# Altium schematic sheet Sheet3.SchDoc
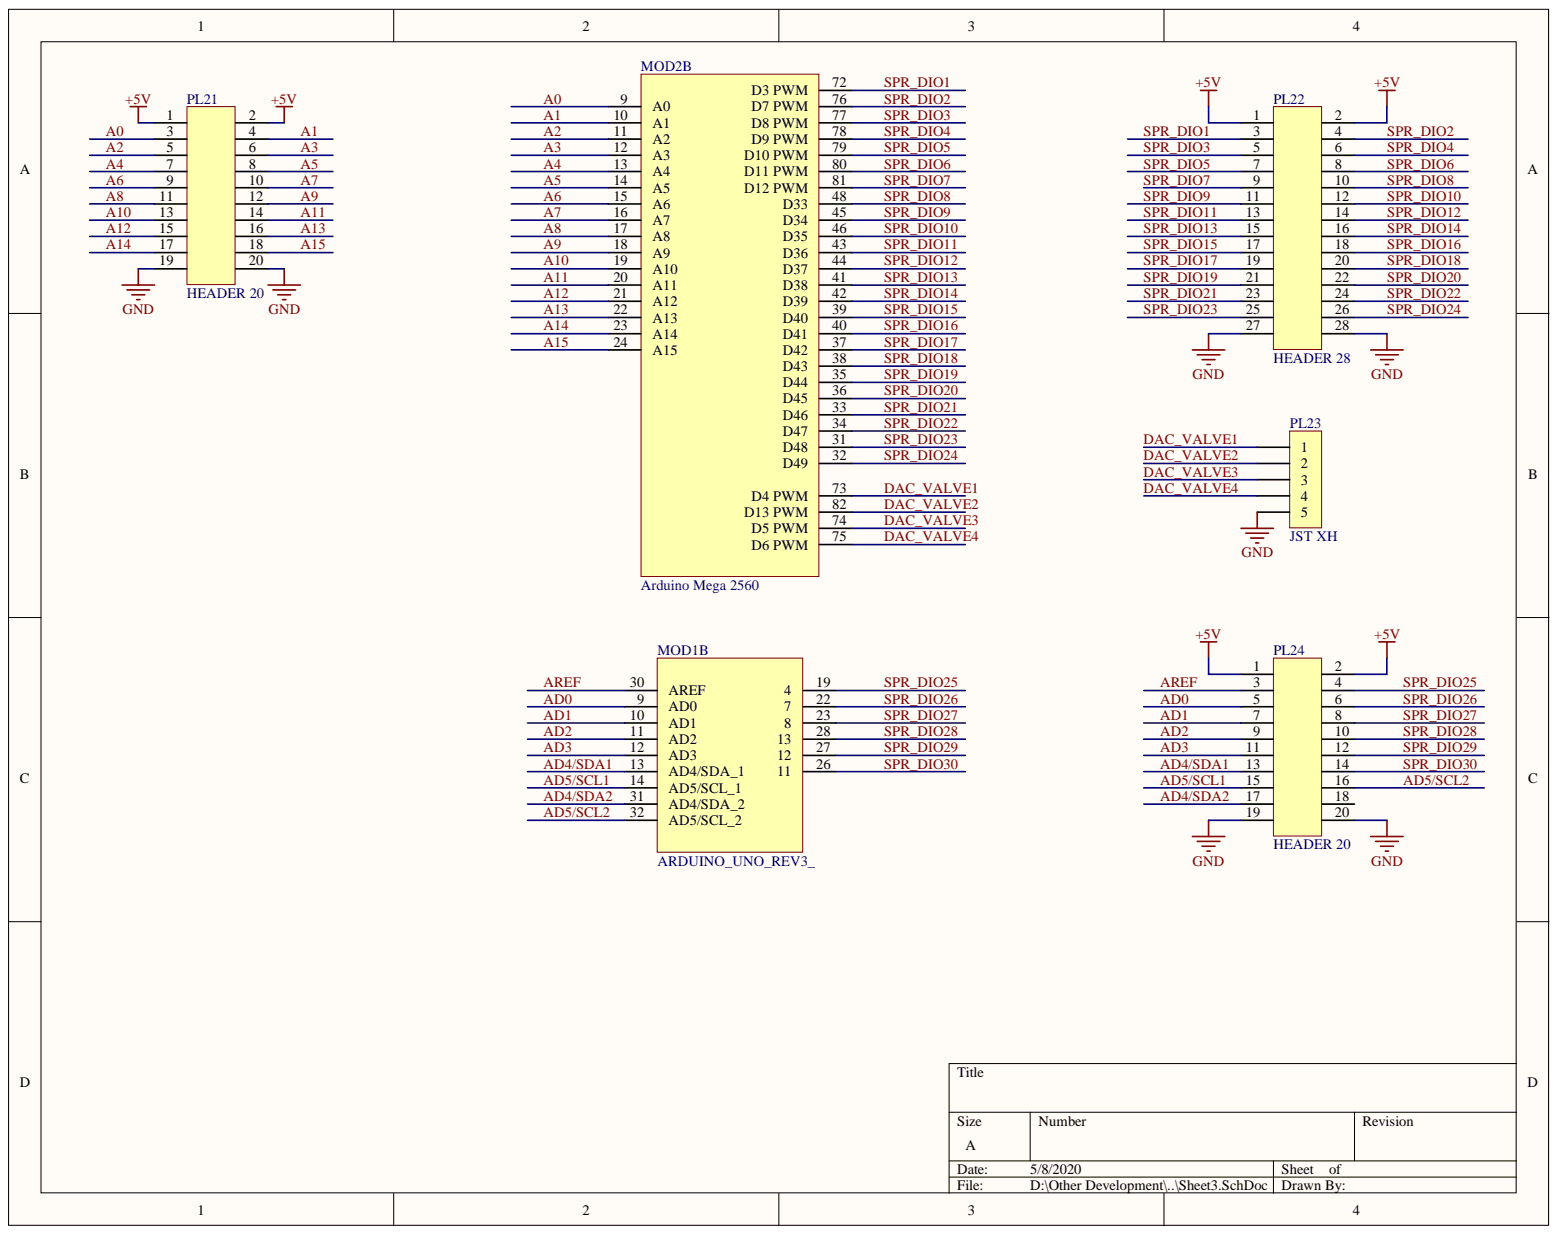
[diagram p0: Sheet3.SchDoc]

## Parts
MOD1  ARDUINO_UNO_REV3_  [ARDUINO_UNO_REV3_DEVELOPMENT_BOARD]  (part B)
MOD2  Arduino Mega 2560  (part B)
PL21  HEADER 20
PL22  HEADER 28
PL23  JST XH
PL24  HEADER 20

## Nets
+5V: PL21.1 PL21.2 PL22.1 PL22.2 PL24.1 PL24.2
GND: PL21.19 PL21.20 PL22.27 PL22.28 PL23.5 PL24.19 PL24.20
A0: MOD2.9(A0) PL21.3
A1: MOD2.10(A1) PL21.4
A2: MOD2.11(A2) PL21.5
A3: MOD2.12(A3) PL21.6
A4: MOD2.13(A4) PL21.7
A5: MOD2.14(A5) PL21.8
A6: MOD2.15(A6) PL21.9
A7: MOD2.16(A7) PL21.10
A8: MOD2.17(A8) PL21.11
A9: MOD2.18(A9) PL21.12
A10: MOD2.19(A10) PL21.13
A11: MOD2.20(A11) PL21.14
A12: MOD2.21(A12) PL21.15
A13: MOD2.22(A13) PL21.16
A14: MOD2.23(A14) PL21.17
A15: MOD2.24(A15) PL21.18
AD0: MOD1.9(AD0) PL24.5
AD1: MOD1.10(AD1) PL24.7
AD2: MOD1.11(AD2) PL24.9
AD3: MOD1.12(AD3) PL24.11
AD4/SDA1: MOD1.13(AD4/SDA_1) PL24.13
AD4/SDA2: MOD1.31(AD4/SDA_2) PL24.17
AD5/SCL1: MOD1.14(AD5/SCL_1) PL24.15
AD5/SCL2: MOD1.32(AD5/SCL_2) PL24.16
AREF: MOD1.30(AREF) PL24.3
DAC_VALVE1: MOD2.73(D4_PWM) PL23.1
DAC_VALVE2: MOD2.82(D13_PWM) PL23.2
DAC_VALVE3: MOD2.74(D5_PWM) PL23.3
DAC_VALVE4: MOD2.75(D6_PWM) PL23.4
SPR_DIO1: MOD2.72(D3_PWM) PL22.3
SPR_DIO2: MOD2.76(D7_PWM) PL22.4
SPR_DIO3: MOD2.77(D8_PWM) PL22.5
SPR_DIO4: MOD2.78(D9_PWM) PL22.6
SPR_DIO5: MOD2.79(D10_PWM) PL22.7
SPR_DIO6: MOD2.80(D11_PWM) PL22.8
SPR_DIO7: MOD2.81(D12_PWM) PL22.9
SPR_DIO8: MOD2.48(D33) PL22.10
SPR_DIO9: MOD2.45(D34) PL22.11
SPR_DIO10: MOD2.46(D35) PL22.12
SPR_DIO11: MOD2.43(D36) PL22.13
SPR_DIO12: MOD2.44(D37) PL22.14
SPR_DIO13: MOD2.41(D38) PL22.15
SPR_DIO14: MOD2.42(D39) PL22.16
SPR_DIO15: MOD2.39(D40) PL22.17
SPR_DIO16: MOD2.40(D41) PL22.18
SPR_DIO17: MOD2.37(D42) PL22.19
SPR_DIO18: MOD2.38(D43) PL22.20
SPR_DIO19: MOD2.35(D44) PL22.21
SPR_DIO20: MOD2.36(D45) PL22.22
SPR_DIO21: MOD2.33(D46) PL22.23
SPR_DIO22: MOD2.34(D47) PL22.24
SPR_DIO23: MOD2.31(D48) PL22.25
SPR_DIO24: MOD2.32(D49) PL22.26
SPR_DIO25: MOD1.19 PL24.4
SPR_DIO26: MOD1.22 PL24.6
SPR_DIO27: MOD1.23 PL24.8
SPR_DIO28: MOD1.28 PL24.10
SPR_DIO29: MOD1.27 PL24.12
SPR_DIO30: MOD1.26 PL24.14

Not connected: PL24 18

## Library data of the parts
MOD1 = ARDUINO_UNO_REV3_DEVELOPMENT_BOARD: Plated Through Hole Protoboard Arduino Mega Platform Evaluation Expansion Board; MP: ARDUINO UNO REV3 DEVELOPMENT BOARD; Availability: Unavailable; Component Kind: Standard; Library Name: Schlib1.SchLib; MF: Arduino; Package: None; Price: None; SnapEDA_Link: https://www.snapeda.com/parts/ARDUINO%20UNO%20REV3%20DEVELOPMENT%20BOARD/Arduino/view-part/424299/?ref=snap
PL21 PL24 = HEADER20: 20 PIN HEADER; Component Kind: Standard; Library Name: Schlib1.SchLib
PL22 = HEADER28: 28 PIN HEADER; Component Kind: Standard; Library Name: Schlib1.SchLib
PL23 = JST XH 2.54_5_1: 5 Pin Connector; Component Kind: Standard; Library Name: Schlib1.SchLib
MOD2 = Arduino Mega 2560: Component Kind: Standard; Library Name: Schlib1.SchLib
